# Altium schematic sheet 4-Bit-Register-SCH.SchDoc(4-Bit-Register-SCH)
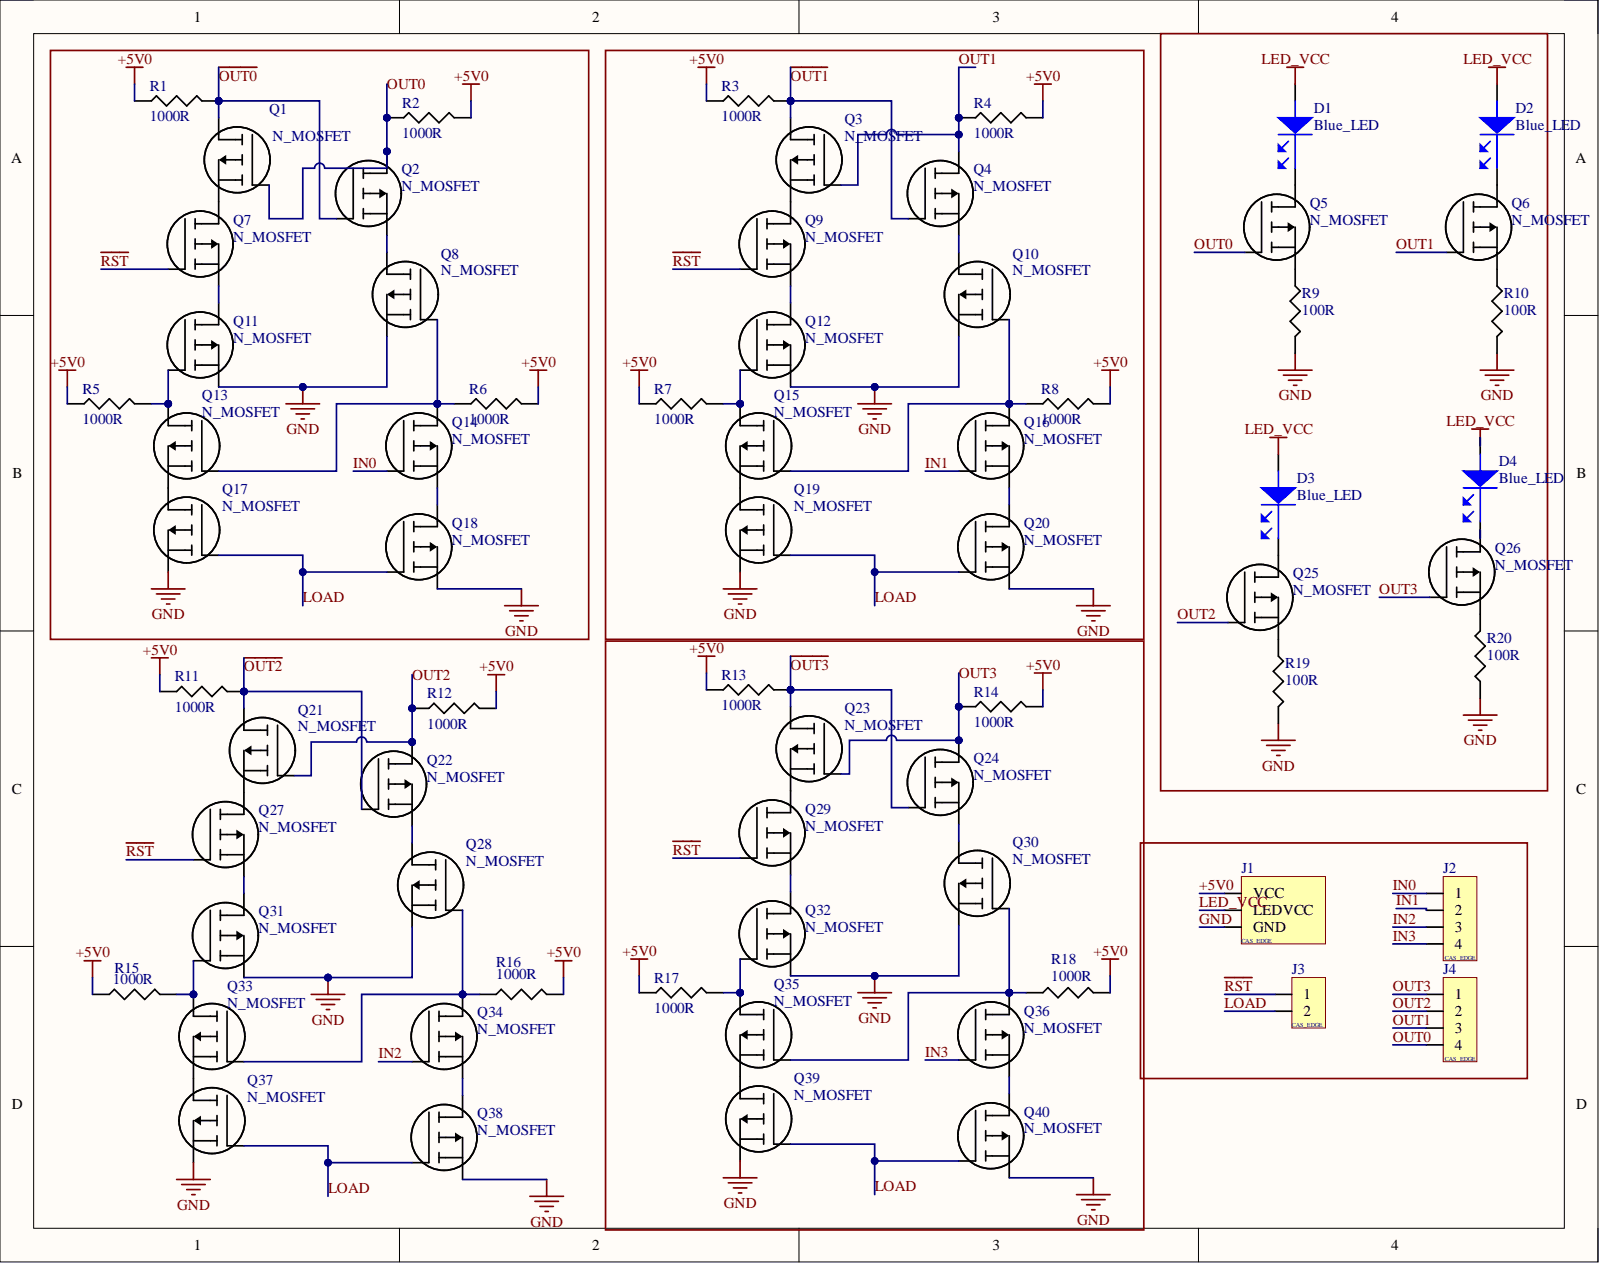
[diagram p0: 4-Bit-Register-SCH.SchDoc]

## Parts
D1  Blue_LED  [LED1206_BLUE]
D2  Blue_LED  [LED1206_BLUE]
D3  Blue_LED  [LED1206_BLUE]
D4  Blue_LED  [LED1206_BLUE]
J1  Jumper_PWR_CLK_castellated  [Jumper_PWR_CLK__Castellated]  (value not drawn)
J2  Jumper_4x1_castellated  [Jumper_1x4_Castellated]  (value not drawn)
J3  Jumper_2x1_castellated  [Jumper_1x2_Castellated]  (value not drawn)
J4  Jumper_4x1_castellated  [Jumper_1x4_Castellated]  (value not drawn)
Q1  N_MOSFET  [SOT23-3]
Q2  N_MOSFET  [SOT23-3]
Q3  N_MOSFET  [SOT23-3]
Q4  N_MOSFET  [SOT23-3]
Q5  N_MOSFET  [SOT23-3]
Q6  N_MOSFET  [SOT23-3]
Q7  N_MOSFET  [SOT23-3]
Q8  N_MOSFET  [SOT23-3]
Q9  N_MOSFET  [SOT23-3]
Q10  N_MOSFET  [SOT23-3]
Q11  N_MOSFET  [SOT23-3]
Q12  N_MOSFET  [SOT23-3]
Q13  N_MOSFET  [SOT23-3]
Q14  N_MOSFET  [SOT23-3]
Q15  N_MOSFET  [SOT23-3]
Q16  N_MOSFET  [SOT23-3]
Q17  N_MOSFET  [SOT23-3]
Q18  N_MOSFET  [SOT23-3]
Q19  N_MOSFET  [SOT23-3]
Q20  N_MOSFET  [SOT23-3]
Q21  N_MOSFET  [SOT23-3]
Q22  N_MOSFET  [SOT23-3]
Q23  N_MOSFET  [SOT23-3]
Q24  N_MOSFET  [SOT23-3]
Q25  N_MOSFET  [SOT23-3]
Q26  N_MOSFET  [SOT23-3]
Q27  N_MOSFET  [SOT23-3]
Q28  N_MOSFET  [SOT23-3]
Q29  N_MOSFET  [SOT23-3]
Q30  N_MOSFET  [SOT23-3]
Q31  N_MOSFET  [SOT23-3]
Q32  N_MOSFET  [SOT23-3]
Q33  N_MOSFET  [SOT23-3]
Q34  N_MOSFET  [SOT23-3]
Q35  N_MOSFET  [SOT23-3]
Q36  N_MOSFET  [SOT23-3]
Q37  N_MOSFET  [SOT23-3]
Q38  N_MOSFET  [SOT23-3]
Q39  N_MOSFET  [SOT23-3]
Q40  N_MOSFET  [SOT23-3]
R1  1000R  [R0805]
R2  1000R  [R0805]
R3  1000R  [R0805]
R4  1000R  [R0805]
R5  1000R  [R0805]
R6  1000R  [R0805]
R7  1000R  [R0805]
R8  1000R  [R0805]
R9  100R  [R1206]
R10  100R  [R1206]
R11  1000R  [R0805]
R12  1000R  [R0805]
R13  1000R  [R0805]
R14  1000R  [R0805]
R15  1000R  [R0805]
R16  1000R  [R0805]
R17  1000R  [R0805]
R18  1000R  [R0805]
R19  100R  [R1206]
R20  100R  [R1206]

## Nets
+5V0: J1.VCC R1.1 R2.2 R3.1 R4.2 R5.1 R6.2 R7.1 R8.2 R11.1 R12.2 R13.1 R14.2 R15.1 R16.2 R17.1 R18.2
GND: J1.GND Q8.2 Q10.2 Q11.2 Q12.2 Q17.2 Q18.2 Q19.2 Q20.2 Q28.2 Q30.2 Q31.2 Q32.2 Q37.2 Q38.2 Q39.2 Q40.2 R9.2 R10.2 R19.2 R20.1
IN0: J2.1 Q14.1
IN1: J2.2 Q16.1
IN2: J2.3 Q34.1
IN3: J2.4 Q36.1
LED_VCC: D1.2 D2.2 D3.2 D4.2 J1.LEDVCC
LOAD: J3.2 Q17.1 Q18.1 Q19.1 Q20.1 Q37.1 Q38.1 Q39.1 Q40.1
~{OUT0}: Q1.3 Q2.1 R1.2
~{OUT1}: Q3.3 Q4.1 R3.2
~{OUT2}: Q21.3 Q22.1 R11.2
~{OUT3}: Q23.3 Q24.1 R13.2
OUT0: J4.4 Q1.1 Q2.3 Q5.1 R2.1
OUT1: J4.3 Q3.1 Q4.3 Q6.1 R4.1
OUT2: J4.2 Q21.1 Q22.3 Q25.1 R12.1
OUT3: J4.1 Q23.1 Q24.3 Q26.1 R14.1
~{RST}: J3.1 Q7.1 Q9.1 Q27.1 Q29.1
NetD1_1: D1.1 Q5.3
NetD2_1: D2.1 Q6.3
NetD3_1: D3.1 Q25.3
NetD4_1: D4.1 Q26.3
NetQ1_2: Q1.2 Q7.3
NetQ2_2: Q2.2 Q8.3
NetQ3_2: Q3.2 Q9.3
NetQ4_2: Q4.2 Q10.3
NetQ5_2: Q5.2 R9.1
NetQ6_2: Q6.2 R10.1
NetQ7_2: Q7.2 Q11.3
NetQ8_1: Q8.1 Q13.1 Q14.3 R6.1
NetQ9_2: Q9.2 Q12.3
NetQ10_1: Q10.1 Q15.1 Q16.3 R8.1
NetQ11_1: Q11.1 Q13.3 R5.2
NetQ12_1: Q12.1 Q15.3 R7.2
NetQ13_2: Q13.2 Q17.3
NetQ14_2: Q14.2 Q18.3
NetQ15_2: Q15.2 Q19.3
NetQ16_2: Q16.2 Q20.3
NetQ21_2: Q21.2 Q27.3
NetQ22_2: Q22.2 Q28.3
NetQ23_2: Q23.2 Q29.3
NetQ24_2: Q24.2 Q30.3
NetQ25_2: Q25.2 R19.1
NetQ26_2: Q26.2 R20.2
NetQ27_2: Q27.2 Q31.3
NetQ28_1: Q28.1 Q33.1 Q34.3 R16.1
NetQ29_2: Q29.2 Q32.3
NetQ30_1: Q30.1 Q35.1 Q36.3 R18.1
NetQ31_1: Q31.1 Q33.3 R15.2
NetQ32_1: Q32.1 Q35.3 R17.2
NetQ33_2: Q33.2 Q37.3
NetQ34_2: Q34.2 Q38.3
NetQ35_2: Q35.2 Q39.3
NetQ36_2: Q36.2 Q40.3

## Library data of the parts
D1-D4 = LED_Blue_1206: Part (NCD1206B1) can be found on JLCPCB Parts Search: https://jlcpcb.com/partdetail/142006-NCD1206B1/C130717; Manufacturer: FOSHAN Nationstar Optoelectronics; Forward Current: 20 mA; Forward Voltage: 3.0V; Luminous Intensity: 100 mcd; Assembly Type: SMT Assembly; Category: Diode; Component Kind: Standard; JLC PCB Part Number: C130717; Manufacturer Part Number: NCD1206B1; Package: 1206; Library Name: Dicrete_CPU_SCHLIB.SchLib
J1 = Jumper_PWR_CLK_castellated: Castellated edge; Component Kind: Standard; Library Name: Dicrete_CPU_SCHLIB.SchLib
J2 J4 = Jumper_4x1_castellated: Castellated Edge; Component Kind: Standard; Library Name: Dicrete_CPU_SCHLIB.SchLib
J3 = Jumper_2x1_castellated: Castellated Edge; Component Kind: Standard; Library Name: Dicrete_CPU_SCHLIB.SchLib
Q1-Q40 = 2n7002_SOT-23: Part (2N7002KQ-71) can be found on JLCPCB Parts Search: https://jlcpcb.com/partdetail/DiodesIncorporated-2N7002KQ7/C111971; Manufacturer: Diodes Zetex; Additional Feature: HIGH RELIABILITY; Drain Current-Max (Abs) (ID): 380mA; Drain Current-Max (ID): 300mA; Drain-source On Resistance-Max: 2R; DS Breakdown Voltage-Min: 60V; Feedback Cap-Max (Crss): 5pF; FET Technology: METAL-OXIDE SEMICONDUCTOR; Number of Elements: 1; Operating Mode: ENHANCEMENT MODE; Package Body Material: Plastic; Polarity/Channel Type: N-CHANNEL; Power Dissipation-Max (Abs): 540mW; Time @ Peak Reflow Temperature-Max (s): 40s; Transistor Application: SWITCHING; Transistor Element Material: SILICON; Category: MOSFETs; Component Kind: Standard; JESD-30 Code: R-PDSO-G3; JESD-609 Code: e3; JLCPCB Part Number: C111971; Manufacturer Part Number: 2N7002KQ-7; Max Operating Temperature: 150°C; Moisture Sensitivity Level: 1; Package Shape: Rectangular; Package Style: SMALL OUTLINEMeter; Peak Reflow Temperature (Cel): 260°C; Pins: 3; Reach SVHC Compliant: Yes; RoHS Compliant: Yes; Surface Mount: Yes; Terminal Finish: Tin; Terminal Form: Gull Wing; Terminal Position: DUAL; Library Name: Dicrete_CPU_SCHLIB.SchLib
R1-R8 R11-R18 = Res_1k_0805: Part (  ARG05FTC1001) can be found on JLCPCB Parts Search: https://jlcpcb.com/partdetail/VikingTech-ARG05FTC1001/C218388; Film: Thin; Percent: +-1%; Component Kind: Standard; JLCPCB part #: C218388; Manufacturer: Viking Tech; Manufacturer Part Number: ARG05FTC1001; Package size: 0805; Library Name: Dicrete_CPU_SCHLIB.SchLib
R9 R10 R19 R20 = Res_100_1206: Part (FCR1206J100RP05Z) can be found on JLCPCB Parts Search: https://jlcpcb.com/partdetail/Ever_OhmsTech-FCR1206J100RP05Z/C153338; Manufacturer: Ever Ohms Tech; Manufacturer Part Number: 1206W4F9103T5E; Resistance: 100 Ohm; Component Kind: Standard; JLCPCB Part #: C18023; Package: 1206; Type: Surface Mount; Library Name: Dicrete_CPU_SCHLIB.SchLib
